# Altium schematic sheet CPU - JTAG, CONTROL.SchDoc
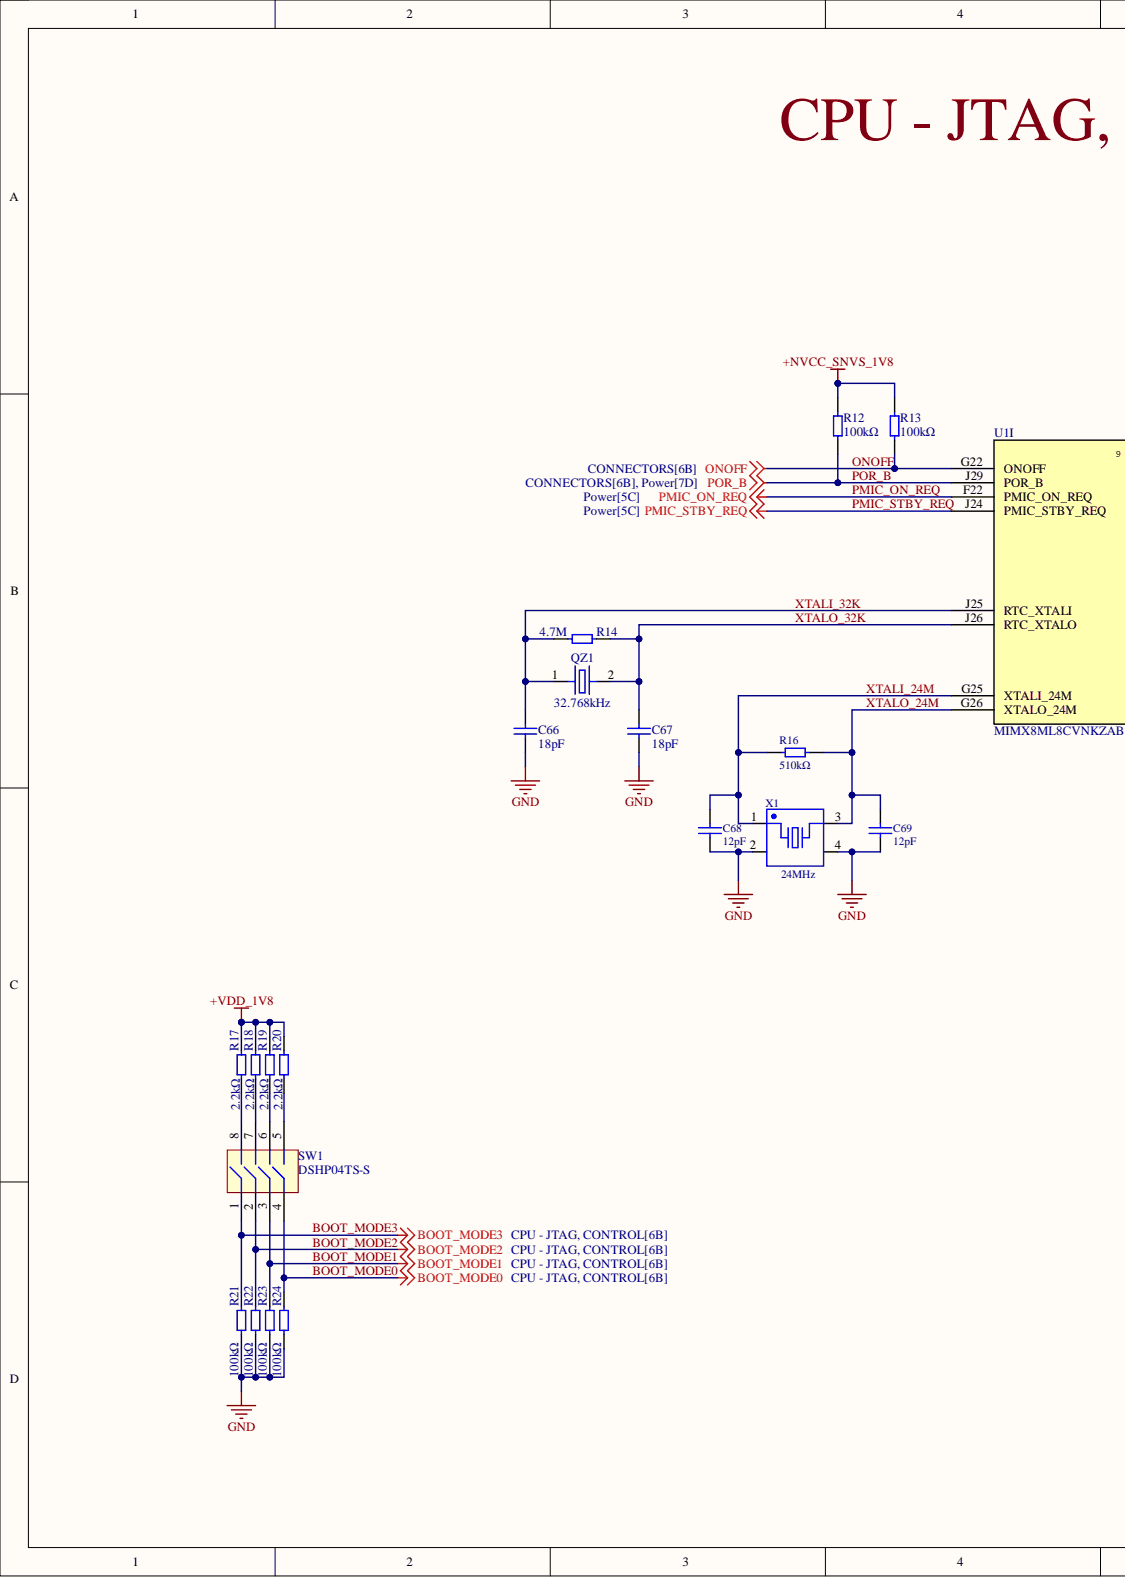
[diagram p0: CPU - JTAG, CONTROL.SchDoc, left]
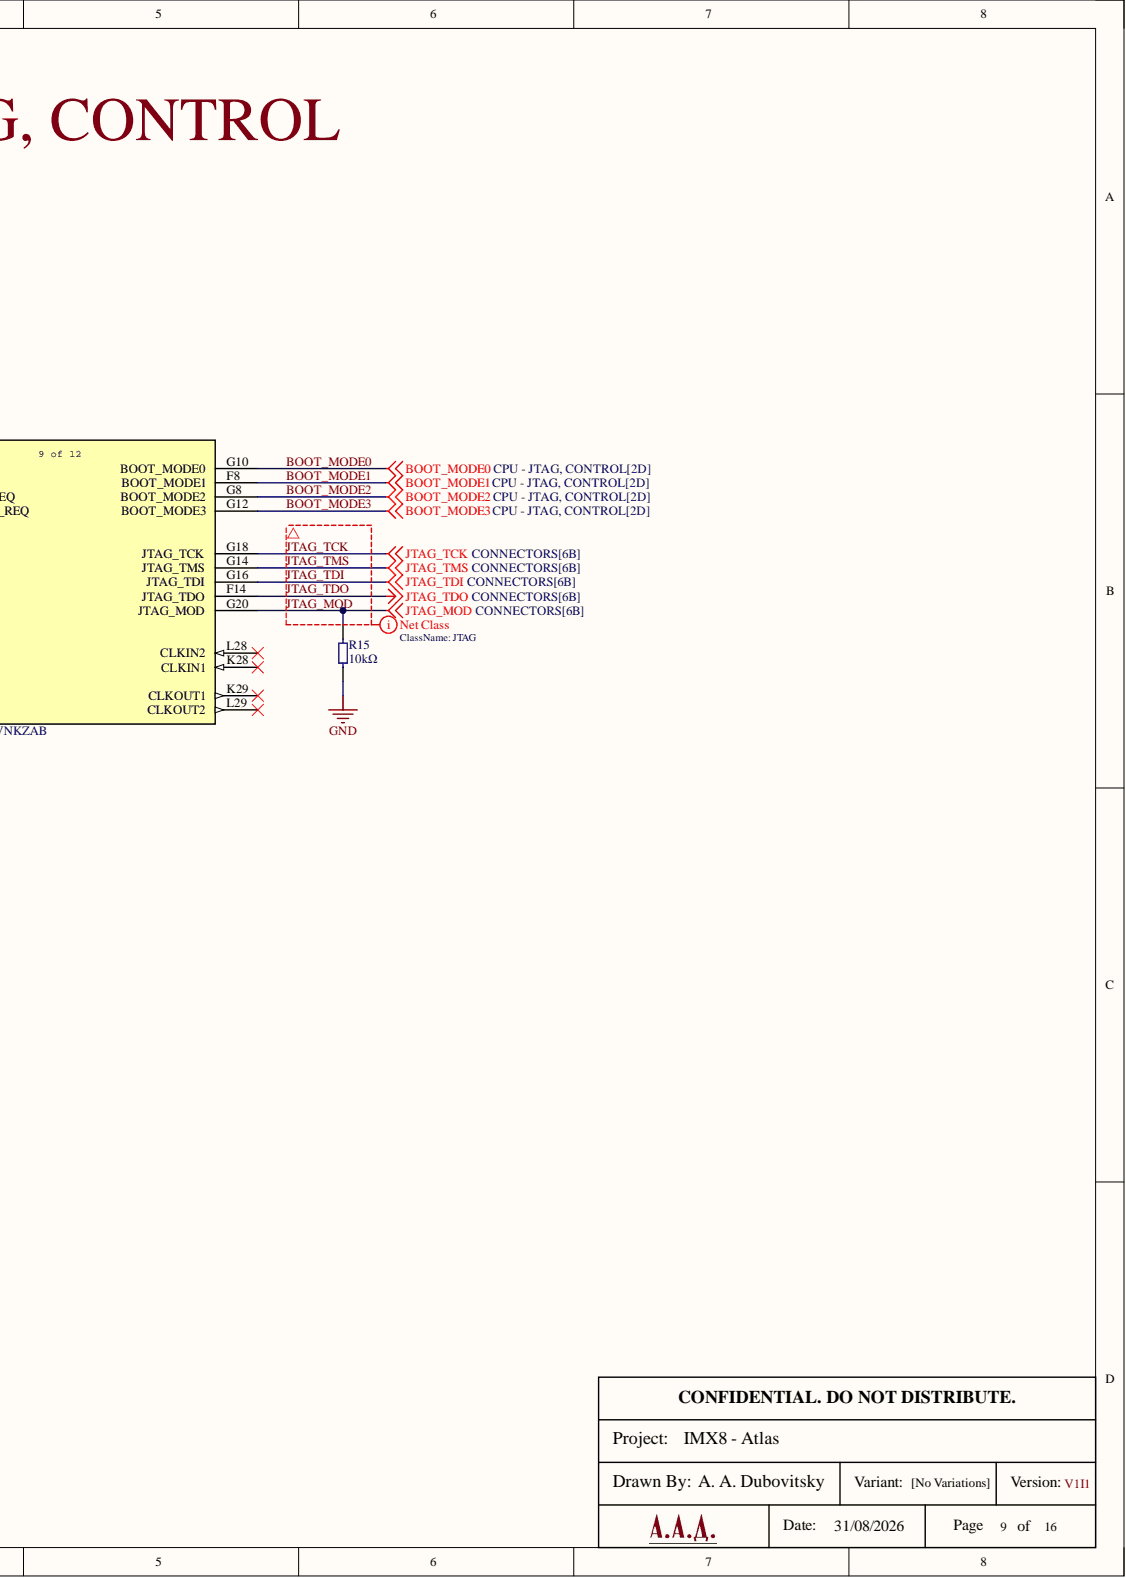
[diagram p1: CPU - JTAG, CONTROL.SchDoc, right]

## Parts
C66  18pF  [CAP 0402_1005]
C67  18pF  [CAP 0402_1005]
C68  12pF  [CAP 0201_0603 MINI]
C69  12pF  [CAP 0201_0603 MINI]
QZ1  32.768kHz  [CRYSTAL-SMD_L3.2-W1.5-1]
R12  100kΩ  [RES 0402_1005]
R13  100kΩ  [RES 0402_1005]
R14  4.7M  [RES 0402_1005]
R15  10kΩ  [RES 0201_0603]
R16  510kΩ  [RES 0402_1005]
R17  2.2kΩ  [RES 0603_1608]
R18  2.2kΩ  [RES 0603_1608]
R19  2.2kΩ  [RES 0603_1608]
R20  2.2kΩ  [RES 0603_1608]
R21  100kΩ  [RES 0402_1005]
R22  100kΩ  [RES 0402_1005]
R23  100kΩ  [RES 0402_1005]
R24  100kΩ  [RES 0402_1005]
SW1  DSHP04TS-S  [SW-SMD_8P-L6.7-W5.4-P1.27-LS8.5]
U1  MIMX8ML8CVNKZAB  [BGA548C50P29X29_1500X1500X148]  (part I)
X1  24MHz  [CRYSTAL-SMD_4P-L3.2-W2.5-BL]

## Nets
+NVCC_SNVS_1V8: R12.1 R13.1
+VDD_1V8: R17.1 R18.1 R19.1 R20.1
GND: C66.1 C67.1 C68.1 C69.1 R15.2 R21.2 R22.2 R23.2 R24.2 X1.2 X1.4
BOOT_MODE0: R24.1 SW1.4 U1.G10(BOOT_MODE0)
BOOT_MODE1: R23.1 SW1.3 U1.F8(BOOT_MODE1)
BOOT_MODE2: R22.1 SW1.2 U1.G8(BOOT_MODE2)
BOOT_MODE3: R21.1 SW1.1 U1.G12(BOOT_MODE3)
JTAG_MOD: R15.1 U1.G20(JTAG_MOD)
JTAG_TCK: U1.G18(JTAG_TCK)
JTAG_TDI: U1.G16(JTAG_TDI)
JTAG_TDO: U1.F14(JTAG_TDO)
JTAG_TMS: U1.G14(JTAG_TMS)
ONOFF: R13.2 U1.G22(ONOFF)
PMIC_ON_REQ: U1.F22(PMIC_ON_REQ)
PMIC_STBY_REQ: U1.J24(PMIC_STBY_REQ)
POR_B: R12.2 U1.J29(POR_B)
XTALI_24M: C68.2 R16.2 U1.G25(XTALI_24M) X1.1
XTALI_32K: C66.2 QZ1.1 R14.2 U1.J25(RTC_XTALI)
XTALO_24M: C69.2 R16.1 U1.G26(XTALO_24M) X1.3
XTALO_32K: C67.2 QZ1.2 R14.1 U1.J26(RTC_XTALO)
NetR17_2: R17.2 SW1.8
NetR18_2: R18.2 SW1.7
NetR19_2: R19.2 SW1.6
NetR20_2: R20.2 SW1.5

Not connected: U1 K28(CLKIN1) K29(CLKOUT1) L28(CLKIN2) L29(CLKOUT2)

## Library data of the parts
C66 C67 = 0402CG180J500NT: Cap.  18pF 50V ±5%; Component Kind: Standard; Parameter 1: 18pF; Library Name: Atlas - Symbols.SchLib
C68 C69 = C384960: Cap. 12pF 50V ±1% 0201; Component Kind: Standard; Library Name: Atlas - Symbols.SchLib
QZ1 = C95361: Crystal Oscillator 32.768kHz 6pF 70kΩ; Component Kind: Standard; Library Name: Atlas - Symbols.SchLib
R12 R13 R21-R24 = C25741: Res. 100kΩ 50V 62.5mW ±1% 0402; Component Kind: Standard; Library Name: Atlas - Symbols.SchLib
R14 = C3013173: Res. 4.7MΩ 50V 62.5mW ±1% 0402; Component Kind: Standard; Library Name: Atlas - Symbols.SchLib
R15 = C138117: Res. 10kΩ 25V 50mW ±5% 0201; Component Kind: Standard; Library Name: Atlas - Symbols.SchLib
R16 = C2906950: Res. 510kΩ 62.5mW 50V ±5% 0402; Component Kind: Standard; Library Name: Atlas - Symbols.SchLib
R17-R20 = 0603WAF2201T5E: Res. 2.2kΩ 100mW, ±1%; .PCB_Checked: A.A.D.; Component Kind: Standard; .Created: A.A.D.; .SCH_Checked: A.A.D.; Library Name: Atlas - Symbols.SchLib
SW1 = C319050: Switch 4 Positions; Component Kind: Standard; Library Name: Atlas - Symbols.SchLib
U1 = MIMX8ML8CVNKZAB: IC ARM MCU; Manufacturer_Name: NXP; Component Kind: Standard; Manufacturer_Part_Number: MIMX8ML8CVNKZAB; RefDes: RefDes; Type: Type; Copyright: Copyright (C) 2026 Ultra Librarian. All rights reserved.; Library Name: Atlas - Symbols.SchLib
X1 = C5241162: Crystal Oscillator 24MHz 12pF; Component Kind: Standard; Library Name: Atlas - Symbols.SchLib
